# Altium schematic sheet Audio.SchDoc(Audio)
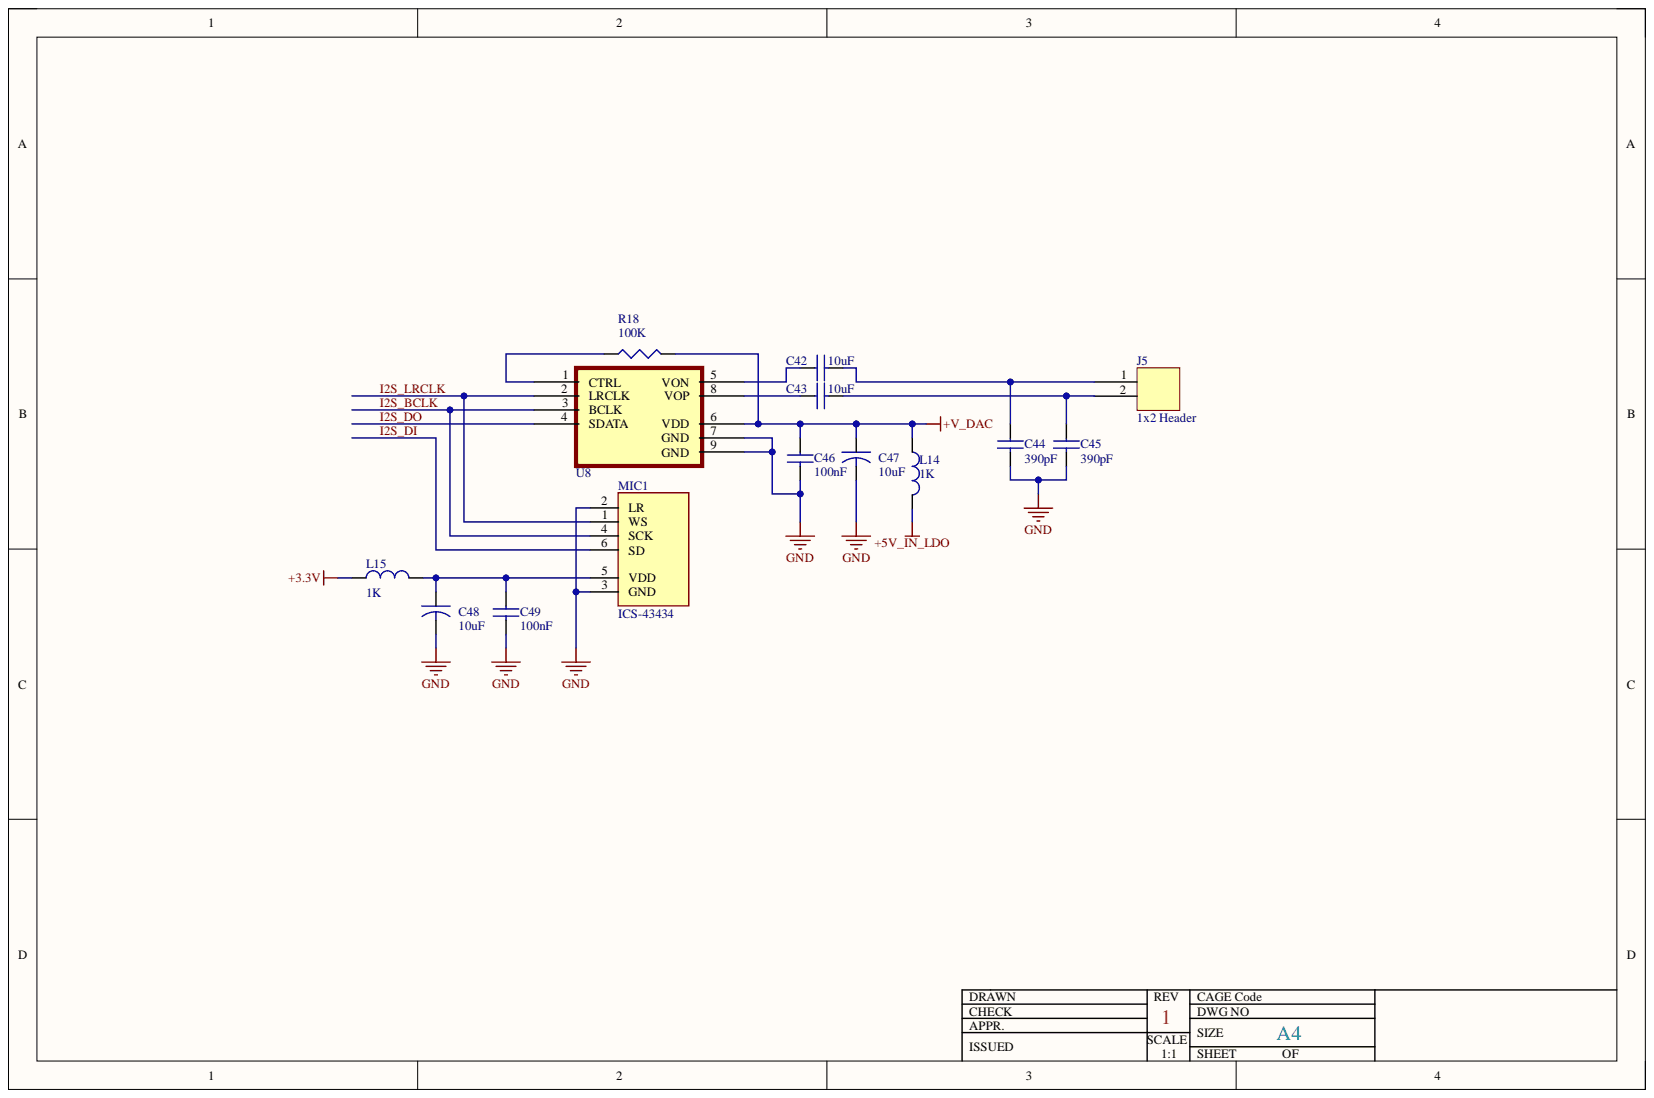
[diagram p0: Audio.SchDoc]

## Parts
C42  value 10uF  [1206 SMD Ceramic Capacitor]  (hidden comment: C1206C106)
C43  value 10uF  [1206 SMD Ceramic Capacitor]  (hidden comment: C1206C106)
C44  value 390pF  [0805 SMD Ceramic Capacitor]  (hidden comment: C0805C391)
C45  value 390pF  [0805 SMD Ceramic Capacitor]  (hidden comment: C0805C391)
C46  value 100nF  [0805 SMD Ceramic Capacitor]  (hidden comment: C0805C104)
C47  value 10uF  [A Case]  (hidden comment: T491A106)
C48  value 10uF  [A Case]  (hidden comment: T491A106)
C49  value 100nF  [0805 SMD Ceramic Capacitor]  (hidden comment: C0805C104)
J5  value 1x2 Header  [1x2 2 Pin Header]  (hidden comment: 1x2 2 Pin Header)
L14  value 1K  [0805 Inductor]  (hidden comment: 0805Ferrite)
L15  value 1K  [0805 Inductor]  (hidden comment: 0805Ferrite)
MIC1  ICS-43434  [MIC_ICS-43434]
R18  value 100K  [0805 Resistor]  (hidden comment: 0805_100K%1)
U8  NS4168  [DDA8]  (value not drawn)

## Nets
+3.3V: L15.1
+5V_IN_LDO: L14.2
+V_DAC: C46.1 C47.1 L14.1 R18.2 U8.6(VDD)
GND: C44.2 C45.2 C46.2 C47.2 C48.2 C49.2 MIC1.2(LR) MIC1.3(GND) U8.7(GND) U8.9(GND)
I2S_BCLK: MIC1.4(SCK) U8.3(BCLK)
I2S_DI: MIC1.6(SD)
I2S_DO: U8.4(SDATA)
I2S_LRCLK: MIC1.1(WS) U8.2(LRCLK)
NetC42_1: C42.1 U8.5(VON)
NetC42_2: C42.2 C44.1 J5.1
NetC43_1: C43.1 U8.8(VOP)
NetC43_2: C43.2 C45.1 J5.2
NetC48_1: C48.1 C49.1 L15.2 MIC1.5(VDD)
NetR18_1: R18.1 U8.1(CTRL)

## Library data of the parts
C42 C43 = C1206C106: Manufacturer: MULTICOMP; Manufacturer Code: MC1206B475K500CT; Capacitance Tolerance: ±10%; Voltage: 50V; Component Kind: Standard; Farnell Code: 2320908; Library Name: Capacitors.SchLib; MOQ: 10; Unit Price: 0,644 €
C44 C45 = C0805C391: Manufacturer: MULTICOMP; Manufacturer Code: C0805C391J5GACTU; Voltage: 50V; Component Kind: Standard; Farnell Code: 1163547; Library Name: Capacitors.SchLib; Package: 0805; Unit Price: 0,17 €
C46 C49 = C0805C104: Manufacturer: KEMET; Manufacturer Code: C0805C104J5RACTU; Voltage: 50V; Component Kind: Standard; Farnell Code: 1414663; Library Name: Capacitors.SchLib; Package: 0805; Unit Price: 0,06 €
C47 C48 = T491A106: Manufacturer: KEMET; Manufacturer Code: T491A106K006AT; Voltage: 6V3; Component Kind: Standard; Farnell Code: 1457412; Library Name: Capacitors.SchLib; Unit Price: 0,143 €
L14 L15 = 0805Ferrite: Manufacturer: TDK; Manufacturer Code: MMZ2012R102A; Current: 500mA; Component Kind: Standard; Farnell Code: 1669719; Library Name: FerriteBead.SchLib; Unit Price: 0,059 €
MIC1 = ICS-43434: 60 Hz ~ 20 kHz Digital, I2S Microphone MEMS (Silicon) 1.65 V ~ 3.63 V Omnidirectional (-26 ±1dB @ 94dB SPL) Solder Pads; Manufacturer: TDK InvenSense; Component Kind: Standard; Library Name: ICS-43434.IntLib; Manufacturer Code: ICS-43434; Package: -; Price Unit: $; Unit Price: 3.12; Value: ICS-43434
R18 = 0805_100K%1: Manufacturer: MULTICOMP; Manufacturer Code: MC01W08051100K; Tolerance: ±1%; Component Kind: Standard; Farnell Code: 9332405; Library Name: Resistors.SchLib; Unit Price: 0,03 €
U8 = NS4168: 2.5Wx1@4Ω Class D Amplifier ESOP-8-EP(3.9x4.9x1.27) Audio Amplifiers ROHS; Manufacturer: Shenzhen Nsiway Tech; Component Kind: Standard; Library Name: NS4168.SchLib; Manufacturer Code: NS4168; Unit Price: 0.598 $; Value: NS4168
J5 = 1x2 2 Pin Header: Component Kind: Standard; Library Name: Header.SchLib
